# Altium schematic sheet Sheet1.SchDoc
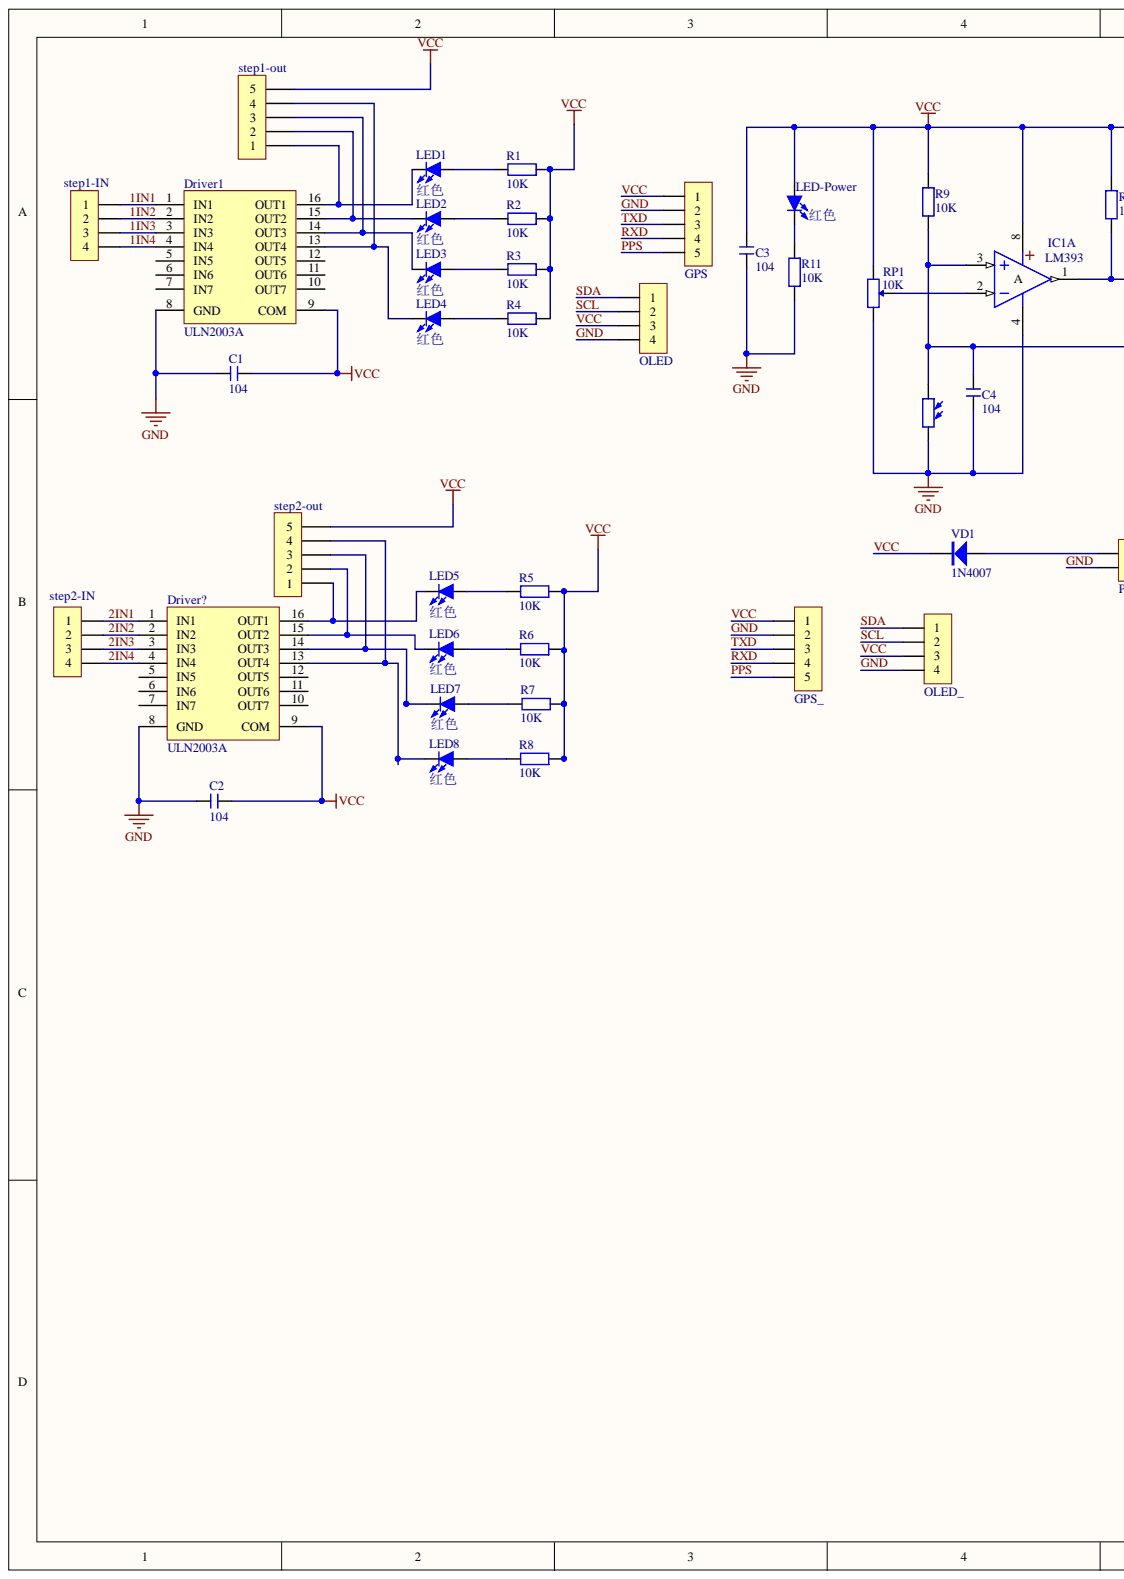
[diagram p0: Sheet1.SchDoc, left]
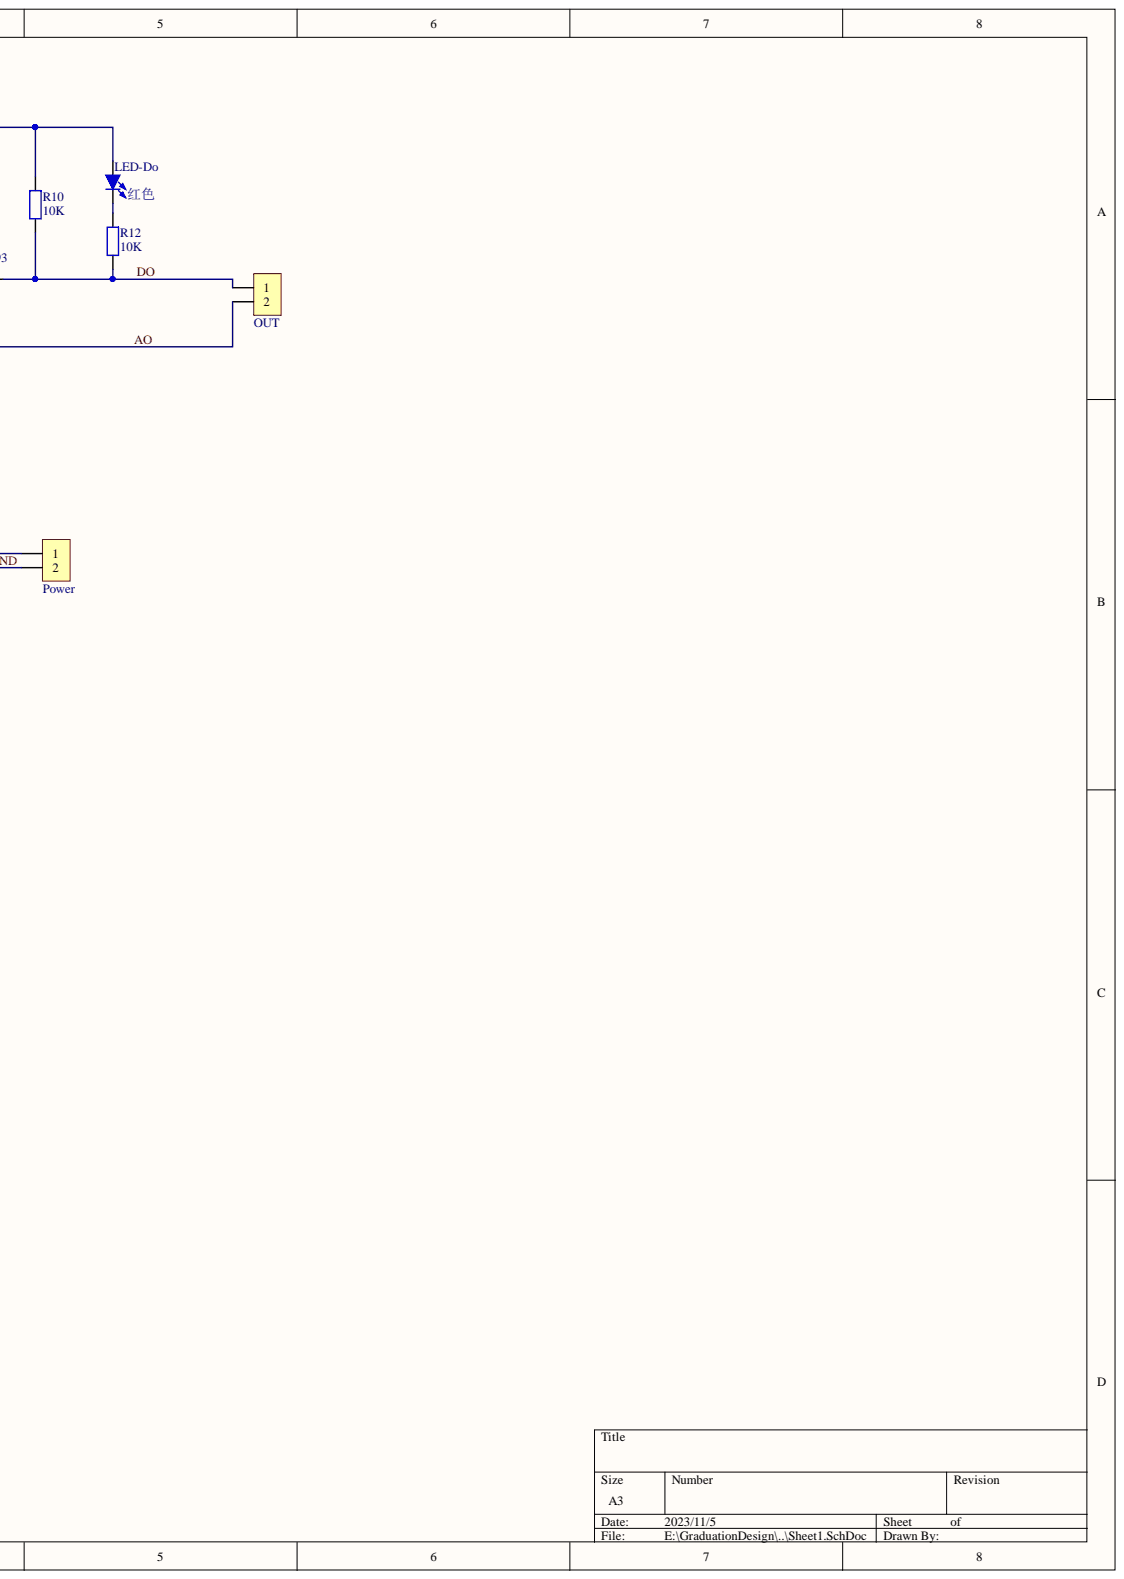
[diagram p1: Sheet1.SchDoc, right]

## Parts
C1  104  [CC 1.8*3.6]
C2  104  [CC 1.8*3.6]
C3  104  [CC 1.8*3.6]
C4  104  [CC 1.8*3.6]
Driver1  ULN2003A  [DIP16-300_MH]
Driver?  ULN2003A  [DIP16-300_MH]
GPS  HDR-1X5  [HDR-1X5]  (value not drawn)
GPS_  HDR-1X5  [HDR-1X5]  (value not drawn)
IC1  LM393  [SOP8_L]  (part A)
LED1  红色  [LED 3MM-B]
LED2  红色  [LED 3MM-B]
LED3  红色  [LED 3MM-B]
LED4  红色  [LED 3MM-B]
LED5  红色  [LED 3MM-B]
LED6  红色  [LED 3MM-B]
LED7  红色  [LED 3MM-B]
LED8  红色  [LED 3MM-B]
LED-Do  红色  [LED 3MM-B]
LED-Power  红色  [LED 3MM-B]
OLED  HDR-1X4  [HDR-1X4]  (value not drawn)
OLED_  HDR-1X4  [HDR-1X4]  (value not drawn)
OUT  HDR-1X2  [HDR-1X2]  (value not drawn)
Power  HDR-1X2  [HDR-1X2]  (value not drawn)
R1  10K  [RS 1/4W]
R2  10K  [RS 1/4W]
R3  10K  [RS 1/4W]
R4  10K  [RS 1/4W]
R5  10K  [RS 1/4W]
R6  10K  [RS 1/4W]
R7  10K  [RS 1/4W]
R8  10K  [RS 1/4W]
R9  10K  [RS 1/4W]
R10  10K  [RS 1/4W]
R11  10K  [RS 1/4W]
R12  10K  [RS 1/4W]
RP1  10K  [3296W]
step1-IN  HDR-1X4  [HDR-1X4]  (value not drawn)
step1-out  StepMotor  [XH_2.54_5P]  (value not drawn)
step2-IN  HDR-1X4  [HDR-1X4]  (value not drawn)
step2-out  StepMotor  [XH_2.54_5P]  (value not drawn)
VD1  1N4007  [DO-41]
  guangmin  [guangDianzu]  (value not drawn)

## Nets
1IN1: Driver1.1(IN1) step1-IN.1
1IN2: Driver1.2(IN2) step1-IN.2
1IN3: Driver1.3(IN3) step1-IN.3
1IN4: Driver1.4(IN4) step1-IN.4
2IN1: Driver?.1(IN1) step2-IN.1
2IN2: Driver?.2(IN2) step2-IN.2
2IN3: Driver?.3(IN3) step2-IN.3
2IN4: Driver?.4(IN4) step2-IN.4
AO: C4.1 IC1.3 OUT.2 R9.1 .2
DO: IC1.1 OUT.1 R10.1 R12.1
GND: C1.1 C2.1 C3.2 C4.2 Driver1.8(GND) Driver?.8(GND) GPS.2 GPS_.2 IC1.4 OLED.4 OLED_.4 Power.2 R11.1 RP1.3 .1
PPS: GPS.5 GPS_.5
RXD: GPS.4 GPS_.4
SCL: OLED.2 OLED_.2
SDA: OLED.1 OLED_.1
TXD: GPS.3 GPS_.3
VCC: C1.2 C2.2 C3.1 Driver1.9(COM) Driver?.9(COM) GPS.1 GPS_.1 IC1.8 LED-Do.1 LED-Power.1 OLED.3 OLED_.3 R1.2 R2.2 R3.2 R4.2 R5.2 R6.2 R7.2 R8.2 R9.2 R10.2 RP1.1 step1-out.5 step2-out.5 VD1.2
NetDriver1_13: Driver1.13(OUT4) LED4.2 step1-out.4
NetDriver1_14: Driver1.14(OUT3) LED3.2 step1-out.3
NetDriver1_15: Driver1.15(OUT2) LED2.2 step1-out.2
NetDriver1_16: Driver1.16(OUT1) LED1.2 step1-out.1
NetDriver?_13: Driver?.13(OUT4) LED8.2 step2-out.4
NetDriver?_14: Driver?.14(OUT3) LED7.2 step2-out.3
NetDriver?_15: Driver?.15(OUT2) LED6.2 step2-out.2
NetDriver?_16: Driver?.16(OUT1) LED5.2 step2-out.1
NetIC1_2: IC1.2 RP1.2
NetLED1_1: LED1.1 R1.1
NetLED2_1: LED2.1 R2.1
NetLED3_1: LED3.1 R3.1
NetLED4_1: LED4.1 R4.1
NetLED5_1: LED5.1 R5.1
NetLED6_1: LED6.1 R6.1
NetLED7_1: LED7.1 R7.1
NetLED8_1: LED8.1 R8.1
NetLED-Do_2: LED-Do.2 R12.2
NetLED-Power_2: LED-Power.2 R11.2
NetPower_1: Power.1 VD1.1

Not connected: Driver1 5(IN5) 6(IN6) 7(IN7) 10(OUT7) 11(OUT6) 12(OUT5); Driver? 5(IN5) 6(IN6) 7(IN7) 10(OUT7) 11(OUT6) 12(OUT5)

## Library data of the parts
C1-C4 = C-CC: 直插瓷片电容; 版权归属: 源创客; BOM名称: 直插瓷片电容; Component Kind: Standard; 学习资源: www.ooocke.com
  C1 C2: Library Name: 3.1   - 电阻-电容-电感.SchLib
  C3 C4: Library Name: 电阻-电容-电感 - 副本.SchLib
GPS GPS_ = Header 5: 5P接插件; 版权归属: 源创客; BOM名称: 5P接插件; Component Kind: Standard; 学习资源: www.ooocke.com; Library Name: 接插件 - 副本.SchLib
IC1 = LM393: 双路电压比较; 版权归属: 源创客; BOM名称: 双路电压比较; Component Kind: Standard; Library Name: 1.1   - IC芯片.SchLib; 学习资源: www.ooocke.com
LED1-LED8 LED-Do LED-Power = LED-3MM: 插件LED; 版权归属: 源创客; BOM名称: 插件LED; Component Kind: Standard; 学习资源: www.ooocke.com; Library Name: LED - 副本.SchLib
OLED OLED_ step1-IN step2-IN = Header 4: 4P接插件; 版权归属: 源创客; BOM名称: 4P接插件; Component Kind: Standard; 学习资源: www.ooocke.com; Library Name: 接插件 - 副本.SchLib
OUT Power = Header 2: 2P接插件; 版权归属: 源创客; BOM名称: 2P接插件; Component Kind: Standard; 学习资源: www.ooocke.com; Library Name: 接插件 - 副本.SchLib
R1-R12 = R-CRR: 直插色环电阻; BOM名称: 色环电阻; 版权归属: 源创客; Component Kind: Standard; Library Name: 3.1   - 电阻-电容-电感.SchLib; 学习资源: www.ooocke.com
RP1 = RP-ID: 插件单联电位器; BOM名称: 插件电位器; 版权归属: 源创客; Component Kind: Standard; Library Name: 3.1   - 电阻-电容-电感.SchLib; 学习资源: www.ooocke.com
step1-out step2-out = SIP5: jointbar; Component Kind: Standard; Library Name: Schlib1.SchLib
VD1 = 1N4007: 整流二极管; 版权归属: 源创客; BOM名称: 整流二极管; Component Kind: Standard; 学习资源: www.ooocke.com; Library Name: 二极管-整流桥 - 副本.SchLib
Driver1 Driver? = ULN2003A: Component Kind: Standard; Library Name: Schlib1.SchLib
 = guangmin: Component Kind: Standard; Library Name: Schlib1.SchLib
